# Altium schematic sheet [3] Sensors.SchDoc("[3] Sensors")
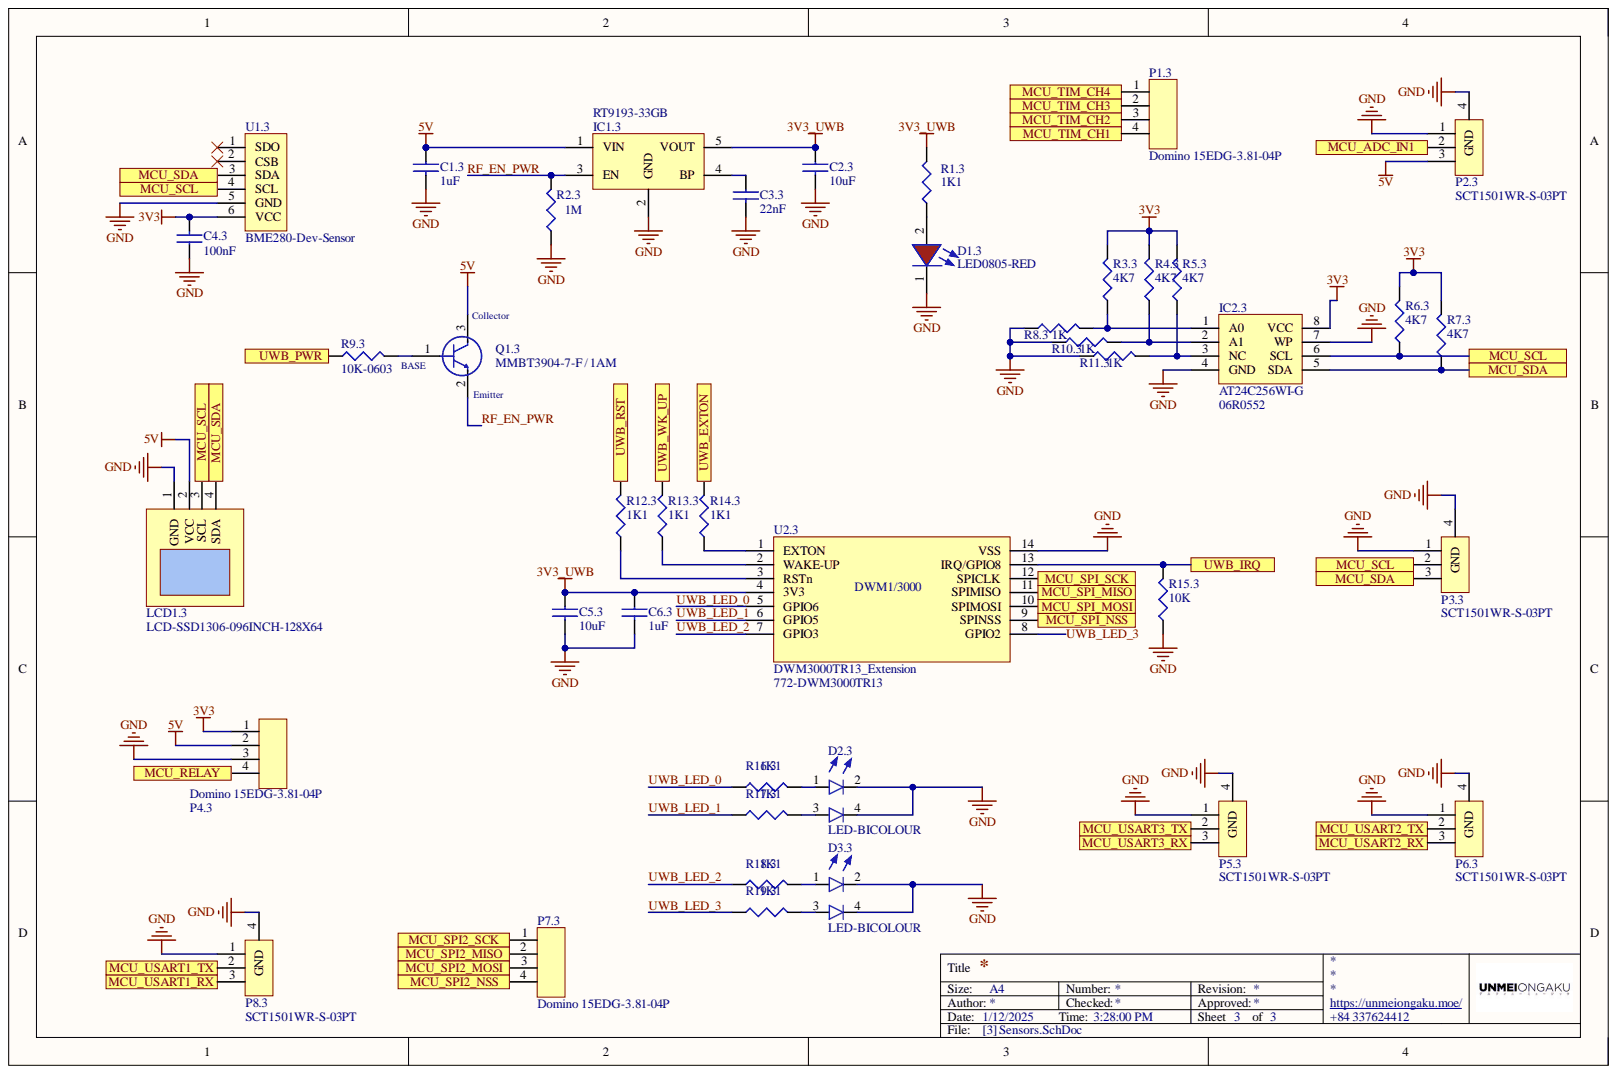
[diagram p0: [3] Sensors.SchDoc]

## Parts
C1.3  1uF  [CAP0603]
C2.3  10uF  [CAP0603]
C3.3  22nF  [CAP0603]
C4.3  100nF  [CAP0603]
C5.3  10uF  [CAP0603]
C6.3  1uF  [CAP0603]
D1.3  LED0805-RED  [LED0805-RED]
D2.3  LED-BICOLOUR  [LED0805-2COLOUR]
D3.3  LED-BICOLOUR  [LED0805-2COLOUR]
IC1.3  RT9193-33GB  [SOT23-5]
IC2.3  AT24C256WI-G  Supplier Part Number 1: 06R0552  [SOIC8]
LCD1.3  LCD-SSD1306-096INCH-128X64  [LCD128X64-SSD1306]
P1.3  Domino 15EDG-3.81-04P  [DOMINO 15EDG3.81 4P]
P2.3  SCT1501WR-S-03PT  [Connector ZH1.5 3P SMD]
P3.3  SCT1501WR-S-03PT  [Connector ZH1.5 3P SMD]
P4.3  Domino 15EDG-3.81-04P  [DOMINO 15EDG3.81 4P]
P5.3  SCT1501WR-S-03PT  [Connector ZH1.5 3P SMD]
P6.3  SCT1501WR-S-03PT  [Connector ZH1.5 3P SMD]
P7.3  Domino 15EDG-3.81-04P  [DOMINO 15EDG3.81 4P]
P8.3  SCT1501WR-S-03PT  [Connector ZH1.5 3P SMD]
Q1.3  MMBT3904-7-F / 1AM  Manufacturer Part Number: MMBT3904-7-F  [SOT23]
R1.3  1K1  [RES0603 - 3D]
R2.3  1M  [RES0603 - 3D]
R3.3  4K7  [RES0603 - 3D]
R4.3  4K7  [RES0603 - 3D]
R5.3  4K7  [RES0603 - 3D]
R6.3  4K7  [RES0603 - 3D]
R7.3  4K7  [RES0603 - 3D]
R8.3  1K  [RES0603 - 3D]
R9.3  10K-0603  [RES0603 - 3D]
R10.3  1K  [RES0603 - 3D]
R11.3  1K  [RES0603 - 3D]
R12.3  1K1  [RES0603 - 3D]
R13.3  1K1  [RES0603 - 3D]
R14.3  1K1  [RES0603 - 3D]
R15.3  10K  [RES0603 - 3D]
R16.3  1K1  [RES0603 - 3D]
R17.3  1K1  [RES0603 - 3D]
R18.3  1K1  [RES0603 - 3D]
R19.3  1K1  [RES0603 - 3D]
U1.3  BME280-Dev-Sensor  [bme280]
U2.3  DWM3000TR13_Extension  Supplier Part Number 1: 772-DWM3000TR13  [DWM3000-EXTEN-PAD]

## Ports
MCU_ADC_IN1, MCU_RELAY, MCU_SCL, MCU_SDA, MCU_SPI2_MISO, MCU_SPI2_MOSI, MCU_SPI2_NSS, MCU_SPI2_SCK, MCU_SPI_MISO, MCU_SPI_MOSI, MCU_SPI_NSS, MCU_SPI_SCK, MCU_TIM_CH1, MCU_TIM_CH2, MCU_TIM_CH3, MCU_TIM_CH4, MCU_USART1_RX, MCU_USART1_TX, MCU_USART2_RX, MCU_USART2_TX, MCU_USART3_RX, MCU_USART3_TX, UWB_EXTON, UWB_IRQ, UWB_PWR, UWB_RST, UWB_WK_UP

## Nets
3V3: C4.3.2 IC2.3.8(VCC) P4.3.1 R3.3.2 R4.3.2 R5.3.2 R6.3.2 R7.3.2 U1.3.6(VCC)
3V3_UWB: C2.3.1 C5.3.1 C6.3.1 IC1.3.5(VOUT) R1.3.2 U2.3.4(3V3)
5V: C1.3.1 IC1.3.1(VIN) LCD1.3.2(VCC) P2.3.3 P4.3.2 Q1.3.3
GND: C1.3.2 C2.3.2 C3.3.2 C4.3.1 C5.3.2 C6.3.2 D1.3.1 D2.3.2 D2.3.4 D3.3.2 D3.3.4 IC1.3.2(GND) IC2.3.4(GND) IC2.3.7(WP) LCD1.3.1(GND) P2.3.1 P2.3.4(GND) P3.3.1 P3.3.4(GND) P4.3.3 P5.3.1 P5.3.4(GND) P6.3.1 P6.3.4(GND) P8.3.1 P8.3.4(GND) R2.3.1 R8.3.1 R10.3.1 R11.3.1 R15.3.1 U1.3.5(GND) U2.3.14(VSS)
MCU_ADC_IN1: P2.3.2 ; port MCU_ADC_IN1
MCU_RELAY: P4.3.4 ; port MCU_RELAY
MCU_SCL: IC2.3.6(SCL) LCD1.3.3(SCL) P3.3.2 R6.3.1 U1.3.4(SCL) ; port MCU_SCL
MCU_SDA: IC2.3.5(SDA) LCD1.3.4(SDA) P3.3.3 R7.3.1 U1.3.3(SDA) ; port MCU_SDA
MCU_SPI2_MISO: P7.3.2 ; port MCU_SPI2_MISO
MCU_SPI2_MOSI: P7.3.3 ; port MCU_SPI2_MOSI
MCU_SPI2_NSS: P7.3.4 ; port MCU_SPI2_NSS
MCU_SPI2_SCK: P7.3.1 ; port MCU_SPI2_SCK
MCU_SPI_MISO: U2.3.11(SPIMISO) ; port MCU_SPI_MISO
MCU_SPI_MOSI: U2.3.10(SPIMOSI) ; port MCU_SPI_MOSI
MCU_SPI_NSS: U2.3.9(SPINSS) ; port MCU_SPI_NSS
MCU_SPI_SCK: U2.3.12(SPICLK) ; port MCU_SPI_SCK
MCU_TIM_CH1: P1.3.4 ; port MCU_TIM_CH1
MCU_TIM_CH2: P1.3.3 ; port MCU_TIM_CH2
MCU_TIM_CH3: P1.3.2 ; port MCU_TIM_CH3
MCU_TIM_CH4: P1.3.1 ; port MCU_TIM_CH4
MCU_USART1_RX: P8.3.3 ; port MCU_USART1_RX
MCU_USART1_TX: P8.3.2 ; port MCU_USART1_TX
MCU_USART2_RX: P6.3.3 ; port MCU_USART2_RX
MCU_USART2_TX: P6.3.2 ; port MCU_USART2_TX
MCU_USART3_RX: P5.3.3 ; port MCU_USART3_RX
MCU_USART3_TX: P5.3.2 ; port MCU_USART3_TX
RF_EN_PWR: IC1.3.3(EN) Q1.3.2 R2.3.2
UWB_EXTON: R14.3.2 ; port UWB_EXTON
UWB_IRQ: R15.3.2 U2.3.13(IRQ/GPIO8) ; port UWB_IRQ
UWB_LED_0: R16.3.1 U2.3.5(GPIO6)
UWB_LED_1: R17.3.1 U2.3.6(GPIO5)
UWB_LED_2: R18.3.1 U2.3.7(GPIO3)
UWB_LED_3: R19.3.1 U2.3.8(GPIO2)
UWB_PWR: R9.3.1 ; port UWB_PWR
UWB_RST: R12.3.2 ; port UWB_RST
UWB_WK_UP: R13.3.2 ; port UWB_WK_UP
NetC3.3_1: C3.3.1 IC1.3.4(BP)
NetD1.3_2: D1.3.2 R1.3.1
NetD2.3_1: D2.3.1 R16.3.2
NetD2.3_3: D2.3.3 R17.3.2
NetD3.3_1: D3.3.1 R18.3.2
NetD3.3_3: D3.3.3 R19.3.2
NetIC2.3_1: IC2.3.1(A0) R3.3.1 R8.3.2
NetIC2.3_2: IC2.3.2(A1) R4.3.1 R10.3.2
NetIC2.3_3: IC2.3.3(NC) R5.3.1 R11.3.2
NetQ1.3_1: Q1.3.1 R9.3.2
NetR12.3_1: R12.3.1 U2.3.3(RSTn)
NetR13.3_1: R13.3.1 U2.3.2(WAKE-UP)
NetR14.3_1: R14.3.1 U2.3.1(EXTON)

Not connected: U1.3 1(SDO) 2(CSB)

## Library data of the parts
C1.3 C6.3 = CC0603KRX7R7BB105: CAP CER 1UF 16V X7R 0603; Manufacturer 1: Yageo; Capacitance: 1µF; Category 1: Capacitors; Tolerance: ±10%; Applications: General Purpose; Component Kind: Standard; ComponentLink1Description: http://www.yageo.com/documents/recent/UPY-GPHC_X7R_6.3V-to-50V_18.pdf; ComponentLink1URL: http://www.yageo.com/documents/recent/UPY-GPHC_X7R_6.3V-to-50V_18.pdf; Description 1: CAP CER 1UF 16V X7R 0603; Failure Rate: -; Features: -; Height - Seated (Max): -; Lead Spacing: -; Lead Style: -; Manufacturer Part Number 1: CC0603KRX7R7BB105; Mounting Type: Surface Mount, MLCC; Operating Temperature: -55°C ~ 125°C; Package / Case: 0603 (1608 Metric); Packaging: Cut Tape (CT); Part Status: Active; Pricing 1: 1=0.1, 10=0.051, 100=0.0227, 500=0.0162, 1000=0.01274 (USD); Ratings: -; RoHS 1: RoHS Compliant; Size / Dimension: 0.063" L x 0.031" W (1.60mm x 0.80mm); Stock 1: 274580; Supplier 1: Digi-Key; Supplier Part Number 1: 311-1446-1-ND; Temperature Coefficient: X7R; Thickness (Max): 0.035" (0.90mm); Value: 1uF; Voltage - Rated: 16V; Library Name: Minh_Lib.SchLib
C2.3 C5.3 = CC0603KRX5R6BB106: CAP CER 10UF 10V X5R 0603; Manufacturer 1: Yageo; Capacitance: 10µF; Category 1: Capacitors; Tolerance: ±10%; Applications: General Purpose; Component Kind: Standard; ComponentLink1Description: http://www.yageo.com/documents/recent/UPY-GPHC_X5R_4V-to-50V_24.pdf; ComponentLink1URL: http://www.yageo.com/documents/recent/UPY-GPHC_X5R_4V-to-50V_24.pdf; Description 1: CAP CER 10UF 10V X5R 0603; Failure Rate: -; Features: -; Height - Seated (Max): -; Lead Spacing: -; Lead Style: -; Manufacturer Part Number 1: CC0603KRX5R6BB106; Mounting Type: Surface Mount, MLCC; Operating Temperature: -55°C ~ 85°C; Package / Case: 0603 (1608 Metric); Packaging: Cut Tape (CT); Part Status: Active; Pricing 1: 1=0.33, 10=0.225, 100=0.1272, 500=0.08982, 1000=0.07858 (USD); Ratings: -; RoHS 1: RoHS Compliant; Size / Dimension: 0.063" L x 0.031" W (1.60mm x 0.80mm); Stock 1: 66566; Supplier 1: Digi-Key; Supplier Part Number 1: 311-1782-1-ND; Temperature Coefficient: X5R; Thickness (Max): 0.035" (0.90mm); Value: 10uF; Voltage - Rated: 10V; Library Name: Minh_Lib.SchLib
C3.3 = CC0603KRX7R9BB223: Multilayer Ceramic Capacitors MLCC - SMD/SMT 22nF 50V X7R 10%; Brand: Yageo; Capacitance: 0.022 uF; Capacitance - pF: 22000 pF; Class: Class 2; Type: General Purpose and High Capacitance Surface Mount Ceramic Multilayer Capacitor; Voltage Rating DC: 50 VDC; Capacitance - nF: 22 nF; Case Code - in: 0603; Case Code - mm: 1608; Category: Multilayer Ceramic Capacitors MLCC - SMD/SMT; Component Kind: Standard; ComponentLink1Description: https://www.mouser.com/datasheet/2/447/UPY-GPHC_X7R_6.3V-to-50V_18-1154002.pdf; ComponentLink1URL: https://www.mouser.com/datasheet/2/447/UPY-GPHC_X7R_6.3V-to-50V_18-1154002.pdf; Dielectric: X7R; Height: 0.8 mm; Length: 1.6 mm; Manufacturer: Yageo; Manufacturer Part Number: CC0603KRX7R9BB223; Maximum Operating Temperature: + 125 C; Minimum Operating Temperature: - 55 C; Minimum Order Quantity: 1; Package / Case: 0603 (1608 metric); Packaging: Cut Tape; Pricing 1: 1=0.100, 10=0.064, 100=0.020, 1000=0.015, 4000=0.011, 8000=0.010, 24000=0.009 (USD); Product: General Type MLCCs; Product Type: Ceramic Capacitors; Reeling: True; RoHS: RoHS Compliant; RoHS - Mouser: Y; Series: CC; Standard Pack Qty: 4000; Stock 1: 23033; Subcategory: Capacitors; Supplier 1: Mouser; Supplier Part Number 1: 603-CC603KRX7R9BB223; Termination: Standard; Termination Style: SMD/SMT; Tolerance: 10 %; Value: 22nF; Width: 0.8 mm; Library Name: Minh_Lib.SchLib
C4.3 = CC0603KRX7R0BB104: CAP CER 0.1UF 100V X7R 0603; Manufacturer: Yageo; Tolerance: ±10%; Applications: General Purpose; Category: Capacitors; Component Kind: Standard; ComponentLink1Description: http://media.digikey.com/pdf/Data%20Sheets/Yageo%20PDFs/NPO,%20X7R%20Mid-voltage.pdf; ComponentLink1URL: http://media.digikey.com/pdf/Data%20Sheets/Yageo%20PDFs/NPO,%20X7R%20Mid-voltage.pdf; Failure Rate: -; Features: -; Height - Seated (Max): -; Lead Spacing: -; Lead Style: -; Manufacturer Part Number: CC0603KRX7R0BB104; Mounting Type: Surface Mount, MLCC; Operating Temperature: -55°C ~ 125°C; Package / Case: 0603 (1608 Metric); Packaging: Cut Tape (CT); Part Status: Active; Pricing 1: 1=0.23, 10=0.156, 100=0.0791, 500=0.05414, 1000=0.0479 (USD); Ratings: -; RoHS: RoHS Compliant; Size / Dimension: 0.063" L x 0.032" W (1.60mm x 0.80mm); Stock 1: 784508; Supplier 1: Digi-Key; Supplier Part Number 1: 311-1523-1-ND; Temperature Coefficient: X7R; Thickness (Max): 0.035" (0.90mm); Value: 100nF; Voltage - Rated: 100V; Library Name: Minh_Lib.SchLib
IC1.3 = RT9193-33GB: IC REG LDO 3.3V 0.3A SOT23-5; Component Kind: Standard; Library Name: Minh_Lib.SchLib
IC2.3 = AT24C256WI-G: CAT24C256 Series 256 Kb (32K X 8)  1.8 V - 5.5 V I2C CMOS Serial EEPROM - SOIC-8; Manufacturer: ON Semiconductor; Manufacturer Part Number: CAT24C256WI-G; Access Time: 500ns; Access Time-Max: 900ns; Density: 256Kb; Frequency: 400kHz; Interface: I2C,Serial; Max Frequency: 100kHz; Max Supply Voltage: 5.5V; Memory Type: Non-Volatile,EEPROM; Min Supply Voltage: 1.8V; Nominal Supply Current: 3mA; Operating Supply Voltage: 5V; Organization: 32KX8; Write Cycle Time-Max (tWC): 5ms; Write Protection: YES; Case/Package: SOIC; Category: EEPROM; Component Kind: Standard; ComponentLink1Description: https://datasheet.ciiva.com/1166/cat24c256-d-1166416.pdf?src-supplier=Newark; ComponentLink1URL: https://datasheet.ciiva.com/1166/cat24c256-d-1166416.pdf?src-supplier=Newark; ComponentLink2Description: https://datasheet.ciiva.com/15123/getdatasheetpartid-257691-15123320.pdf?src-supplier=Verical; ComponentLink2URL: https://datasheet.ciiva.com/15123/getdatasheetpartid-257691-15123320.pdf?src-supplier=Verical; ComponentLink3Description: https://datasheet.ciiva.com/5700/cat24c256-d-5700793.pdf?src-supplier=Farnell; ComponentLink3URL: https://datasheet.ciiva.com/5700/cat24c256-d-5700793.pdf?src-supplier=Farnell; ComponentLink4Description: https://datasheet.ciiva.com/8072/cat24c256-d-8072141.pdf?src-supplier=Element14; ComponentLink4URL: https://datasheet.ciiva.com/8072/cat24c256-d-8072141.pdf?src-supplier=Element14; ComponentLink5Description: https://datasheet.ciiva.com/4859/cat24c256-d-105771-4859399.pdf?src-supplier=Mouser; ComponentLink5URL: https://datasheet.ciiva.com/4859/cat24c256-d-105771-4859399.pdf?src-supplier=Mouser; ComponentLink6Description: https://datasheet.ciiva.com/3185/cat24c256-d-3185116.pdf?src-supplier=Avnet; ComponentLink6URL: https://datasheet.ciiva.com/3185/cat24c256-d-3185116.pdf?src-supplier=Avnet; ComponentLink7Description: https://datasheet.ciiva.com/16456/cat24c256-d-16456381.pdf?src-supplier=Future+Electronics; ComponentLink7URL: https://datasheet.ciiva.com/16456/cat24c256-d-16456381.pdf?src-supplier=Future+Electronics; ComponentLink8Description: https://datasheet.ciiva.com/1912/cat24c256-d-1912247.pdf?src-supplier=Digi-Key; ComponentLink8URL: https://datasheet.ciiva.com/1912/cat24c256-d-1912247.pdf?src-supplier=Digi-Key; Contact Plating: Gold; Lead Free: Lead Free; Max Operating Temperature: 85°C; Memory Size: 256Kb; Min Operating Temperature: -40°C; Mount: Surface Mount; Number of Pins: 8; Package Quantity: 100; Packaging: Rail/Tube; Radiation Hardening: No; Reach SVHC Compliant: Yes; RoHS: Yes; RoHS Compliant: Yes; Series: -; Supplier 1: Newark; Supply Current: 3mA; Supply Voltage-Nom: 5.5V; Library Name: Minh_Lib.SchLib
Q1.3 = MMBT3904-7-F / 1AM: Transistor General Purpose BJT NPN 40 Volt 0.2A Automotive 3-Pin SOT-23; Manufacturer: Diodes Zetex; Collector Base Voltage (VCBO): 60V; Collector Emitter Breakdown Voltage: 40V; Collector Emitter Saturation Voltage: 300mV; Continuous Collector Current: 200mA; Element Configuration: Single; Emitter Base Voltage (VEBO): 6V; Frequency: 300MHz; hFE Min: 100; Max Power Dissipation: 300mW; Number of Elements: 1; Power Dissipation: 350mW; Case/Package: SOT-23; Category: BJTs; Collector Emitter Voltage (VCEO): 40V; Collector-emitter Voltage-Max: 300mV; Component Kind: Standard; ComponentLink1Description: https://datasheet.ciiva.com/11201/ds30036-11201778.pdf?src-supplier=Avnet; ComponentLink1URL: https://datasheet.ciiva.com/11201/ds30036-11201778.pdf?src-supplier=Avnet; ComponentLink2Description: https://datasheet.ciiva.com/15138/getdatasheetpartid-53265-15138780.pdf?src-supplier=Verical; ComponentLink2URL: https://datasheet.ciiva.com/15138/getdatasheetpartid-53265-15138780.pdf?src-supplier=Verical; ComponentLink3Description: https://datasheet.ciiva.com/22711/1915516-22711750.pdf?src-supplier=Element14; ComponentLink3URL: https://datasheet.ciiva.com/22711/1915516-22711750.pdf?src-supplier=Element14; ComponentLink4Description: https://datasheet.ciiva.com/11198/ds30036-11198999.pdf?src-supplier=Avnet; ComponentLink4URL: https://datasheet.ciiva.com/11198/ds30036-11198999.pdf?src-supplier=Avnet; ComponentLink5Description: https://datasheet.ciiva.com/6361/ds30036-6361256.pdf?src-supplier=Digi-Key; ComponentLink5URL: https://datasheet.ciiva.com/6361/ds30036-6361256.pdf?src-supplier=Digi-Key; ComponentLink6Description: https://datasheet.ciiva.com/6361/ds30036-6361254.pdf?src-supplier=Digi-Key; ComponentLink6URL: https://datasheet.ciiva.com/6361/ds30036-6361254.pdf?src-supplier=Digi-Key; Contact Plating: Tin,Matte; Gain Bandwidth Product: 300MHz; Height: 1mm; Lead Free: Lead Free; Length: 3.05mm; Max Breakdown Voltage: 40V; Max Collector Current: 200mA; Max Frequency: 300MHz; Max Operating Temperature: 150°C; Min Operating Temperature: -55°C; Mount: Surface Mount; Number of Pins: 3; Package Quantity: 3000; Packaging: Tape and Reel; Polarity: NPN; Pricing 1: 3000=0.02271, 6000=0.02048, 15000=0.01781, 30000=0.01603, 75000=0.01425 (USD); Radiation Hardening: No; REACH SVHC: No SVHC; RoHS: Yes; RoHS Compliant: Yes; Series: -; Stock 1: 468030; Supplier 1: Digi-Key; Supplier Part Number 1: MMBT3904-FDITR-ND; Transition Frequency: 300MHz; Voltage Rating (DC): 40V; Weight: 0.000282oz; Width: 1.4mm; Library Name: Minh_Lib.SchLib
R1.3 R12.3 R13.3 R14.3 R16.3 R17.3 R18.3 R19.3 = RC0603FR-071K1L: RES SMD 1.1K OHM 1% 1/10W 0603; Manufacturer: Yageo; Power (Watts): 0.1W, 1/10W; Temperature Coefficient: ±100ppm/°C; Category: Resistors; Component Kind: Standard; ComponentLink1Description: http://www.yageo.com/documents/recent/PYu-RC_Group_51_RoHS_L_7.pdf; ComponentLink1URL: http://www.yageo.com/documents/recent/PYu-RC_Group_51_RoHS_L_7.pdf; Composition: Thick Film; Failure Rate: -; Features: Moisture Resistant; Height - Seated (Max): 0.022" (0.55mm); Manufacturer Part Number: RC0603FR-071K1L; Operating Temperature: -55°C ~ 155°C; Package / Case: 0603 (1608 Metric); Packaging: Cut Tape (CT); Part Status: Active; Pricing 1: 1=0.1, 10=0.015, 100=0.006, 1000=0.00268, 2500=0.00233 (USD); RoHS: RoHS Compliant; Size / Dimension: 0.063" L x 0.032" W (1.60mm x 0.80mm); Stock 1: 427612; Supplier 1: Digi-Key; Supplier Device Package: 0603; Supplier Part Number 1: 311-1.10KHRCT-ND; Tolerance: ±1%; Value: 1K1; Library Name: Minh_Lib.SchLib
R2.3 = RC0603JR-071ML: RES SMD 1M OHM 5% 1/10W 0603; Manufacturer 1: Yageo; Category 1: Resistors; Power (Watts): 0.1W, 1/10W; Temperature Coefficient: ±100ppm/°C; Component Kind: Standard; ComponentLink1Description: http://www.yageo.com/documents/recent/PYu-RC_Group_51_RoHS_L_7.pdf; ComponentLink1URL: http://www.yageo.com/documents/recent/PYu-RC_Group_51_RoHS_L_7.pdf; Composition: Thick Film; Description 1: RES SMD 1M OHM 5% 1/10W 0603; Failure Rate: -; Features: Moisture Resistant; Height - Seated (Max): 0.022" (0.55mm); Manufacturer Part Number 1: RC0603JR-071ML; Operating Temperature: -55°C ~ 155°C; Package / Case: 0603 (1608 Metric); Packaging: Cut Tape (CT); Part Status: Active; Pricing 1: 1=0.1, 10=0.011, 100=0.0044, 1000=0.00198, 2500=0.00172 (USD); Resistance (Ohms): 1M; RoHS 1: RoHS Compliant; Size / Dimension: 0.063" L x 0.031" W (1.60mm x 0.80mm); Stock 1: 1727458; Supplier 1: Digi-Key; Supplier Device Package: 0603; Supplier Part Number 1: 311-1.0MGRCT-ND; Tolerance: ±5%; Value: 1M; Library Name: Minh_Lib.SchLib
R3.3 R4.3 R5.3 R6.3 R7.3 = RC0603JR-074K7L: RES SMD 4.7K OHM 5% 1/10W 0603; Manufacturer: Yageo; Power (Watts): 0.1W, 1/10W; Temperature Coefficient: ±100ppm/°C; Category: Resistors; Component Kind: Standard; ComponentLink1Description: http://www.yageo.com/documents/recent/PYu-RC_Group_51_RoHS_L_7.pdf; ComponentLink1URL: http://www.yageo.com/documents/recent/PYu-RC_Group_51_RoHS_L_7.pdf; Composition: Thick Film; Failure Rate: -; Features: Moisture Resistant; Height - Seated (Max): 0.022" (0.55mm); Manufacturer Part Number: RC0603JR-074K7L; Operating Temperature: -55°C ~ 155°C; Package / Case: 0603 (1608 Metric); Packaging: Cut Tape (CT); Part Status: Active; Pricing 1: 1=0.1, 10=0.011, 100=0.0046, 1000=0.00207, 2500=0.0018 (USD); RoHS: RoHS Compliant; Size / Dimension: 0.063" L x 0.032" W (1.60mm x 0.80mm); Stock 1: 4925196; Supplier 1: Digi-Key; Supplier Device Package: 0603; Supplier Part Number 1: 311-4.7KGRCT-ND; Tolerance: ±5%; Value: 4K7; Library Name: Minh_Lib.SchLib
R8.3 R10.3 R11.3 = RC0603JR-071KL: RES SMD 1K OHM 5% 1/10W 0603; Manufacturer 1: Yageo; Category 1: Resistors; Power (Watts): 0.1W, 1/10W; Temperature Coefficient: ±100ppm/°C; Component Kind: Standard; ComponentLink1Description: http://www.yageo.com/documents/recent/PYu-RC_Group_51_RoHS_L_7.pdf; ComponentLink1URL: http://www.yageo.com/documents/recent/PYu-RC_Group_51_RoHS_L_7.pdf; Composition: Thick Film; Description 1: RES SMD 1K OHM 5% 1/10W 0603; Failure Rate: -; Features: Moisture Resistant; Height - Seated (Max): 0.022" (0.55mm); Manufacturer Part Number 1: RC0603JR-071KL; Operating Temperature: -55°C ~ 155°C; Package / Case: 0603 (1608 Metric); Packaging: Cut Tape (CT); Part Status: Active; Pricing 1: 1=0.1, 10=0.011, 100=0.0044, 1000=0.00198, 2500=0.00172 (USD); Resistance (Ohms): 1k; RoHS 1: RoHS Compliant; Size / Dimension: 0.063" L x 0.031" W (1.60mm x 0.80mm); Stock 1: 2853955; Supplier 1: Digi-Key; Supplier Device Package: 0603; Supplier Part Number 1: 311-1.0KGRCT-ND; Tolerance: ±5%; Value: 1K; Library Name: Minh_Lib.SchLib
R9.3 = RC0603JR-0710KL: RES SMD 10K OHM 5% 1/10W 0603; Manufacturer 1: Yageo; Category 1: Resistors; Power (Watts): 0.1W, 1/10W; Temperature Coefficient: ±100ppm/°C; Component Kind: Standard; ComponentLink1Description: http://www.yageo.com/documents/recent/PYu-RC_Group_51_RoHS_L_7.pdf; ComponentLink1URL: http://www.yageo.com/documents/recent/PYu-RC_Group_51_RoHS_L_7.pdf; Composition: Thick Film; Description 1: RES SMD 10K OHM 5% 1/10W 0603; Failure Rate: -; Features: Moisture Resistant; Height - Seated (Max): 0.022" (0.55mm); Manufacturer Part Number 1: RC0603JR-0710KL; Operating Temperature: -55°C ~ 155°C; Package / Case: 0603 (1608 Metric); Packaging: Cut Tape (CT); Part Status: Active; Pricing 1: 1=0.1, 10=0.011, 100=0.0044, 1000=0.00198, 2500=0.00172 (USD); Resistance (Ohms): 10k; RoHS 1: RoHS Compliant; Size / Dimension: 0.063" L x 0.031" W (1.60mm x 0.80mm); Stock 1: 3056463; Supplier 1: Digi-Key; Supplier Device Package: 0603; Supplier Part Number 1: 311-10KGRCT-ND; Tolerance: ±5%; Value: 10K; Library Name: Minh_Lib.SchLib
R15.3 = RC0603FR-0710KL: RES SMD 10K OHM 1% 1/10W 0603; Manufacturer: Yageo; Power (Watts): 0.1W, 1/10W; Temperature Coefficient: ±100ppm/°C; Category: Resistors; Component Kind: Standard; ComponentLink1Description: http://www.yageo.com/documents/recent/PYu-RC_Group_51_RoHS_L_7.pdf; ComponentLink1URL: http://www.yageo.com/documents/recent/PYu-RC_Group_51_RoHS_L_7.pdf; Composition: Thick Film; Failure Rate: -; Features: Moisture Resistant; Height - Seated (Max): 0.022" (0.55mm); Manufacturer Part Number: RC0603FR-0710KL; Operating Temperature: -55°C ~ 155°C; Package / Case: 0603 (1608 Metric); Packaging: Cut Tape (CT); Part Status: Active; Pricing 1: 1=0.1, 10=0.015, 100=0.006, 1000=0.00268, 2500=0.00233 (USD); RoHS: RoHS Compliant; Size / Dimension: 0.063" L x 0.032" W (1.60mm x 0.80mm); Stock 1: 16545228; Supplier 1: Digi-Key; Supplier Device Package: 0603; Supplier Part Number 1: 311-10.0KHRCT-ND; Tolerance: ±1%; Value: 10K; Library Name: Minh_Lib.SchLib
U2.3 = DWM3000TR13_Extension: UWB Transceiver Module; Manufacturer: Qorvo; Category: RF Receivers, Transceivers; Component Kind: Standard; Manufacturer Part Number: DWM3000TR13; Pricing 1: 1=23.62, 10=23.62, 50=20.19, 100=17.18, 1000=12.71, 10000=12.71 (USD); RoHS: Yes; Stock 1: 12093; Supplier 1: Mouser; Library Name: Minh_Lib.SchLib
D1.3 = LED0805-RED: Component Kind: Standard; Library Name: Minh_Lib.SchLib
D2.3 D3.3 = LED-BICOLOUR: Component Kind: Standard; Library Name: Minh_Lib.SchLib
LCD1.3 = LCD-SSD1306-096INCH-128X64: Component Kind: Standard; Library Name: Minh_Lib.SchLib
P1.3 P4.3 P7.3 = Domino 15EDG-3.81-04P: Component Kind: Standard; Library Name: Minh_Lib.SchLib
P2.3 P3.3 P5.3 P6.3 P8.3 = SCT1501WR-S-03PT: Component Kind: Standard; Library Name: Minh_Lib.SchLib
U1.3 = BME280-Dev-Sensor: Component Kind: Standard; Library Name: Minh_Lib.SchLib
